# Altium schematic sheet RPI-TPS-GK - USB.SchDoc
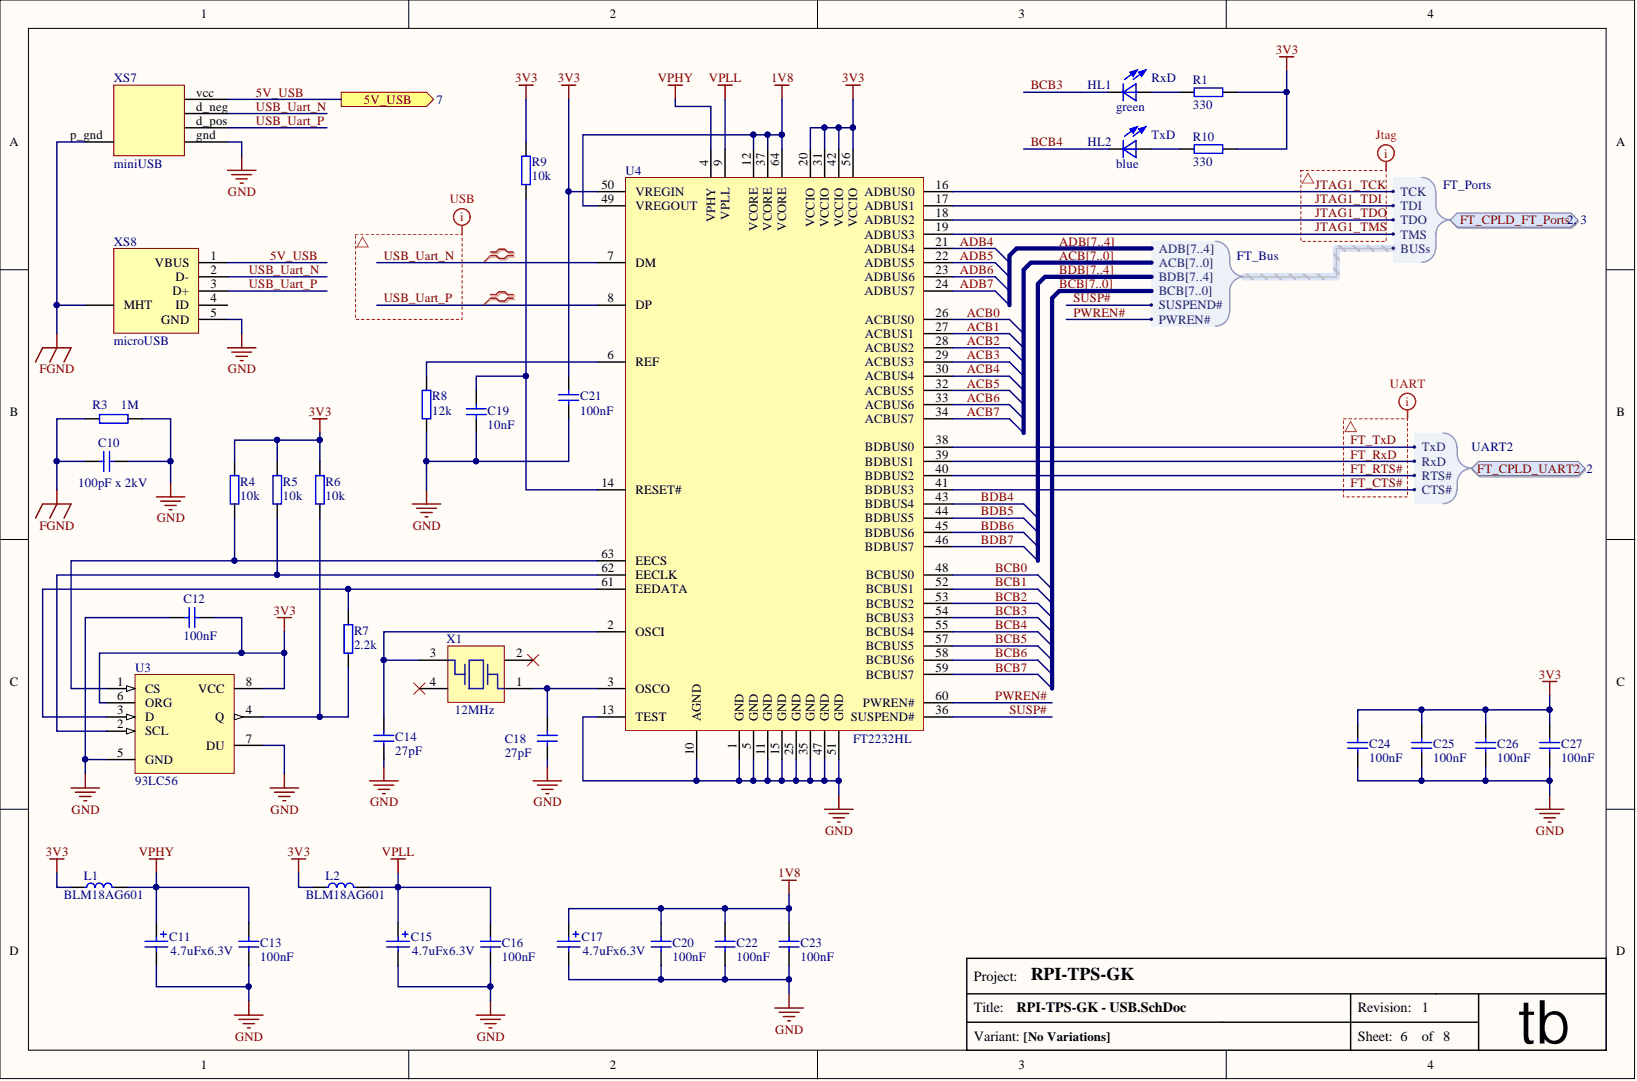
[diagram p0: RPI-TPS-GK - USB.SchDoc]

## Parts
C10  value 100pF x 2kV  [C1206]  (hidden comment: CAP)
C11  value 4.7uFx6.3V  [CAP TAN 0805 2012 SIZE R]  (hidden comment: uF)
C12  value 100nF  [C0603]  (hidden comment: CAP)
C13  value 100nF  [C0603]  (hidden comment: CAP)
C14  value 27pF  [C0603]  (hidden comment: CAP)
C15  value 4.7uFx6.3V  [CAP TAN 0805 2012 SIZE R]  (hidden comment: uF)
C16  value 100nF  [C0603]  (hidden comment: CAP)
C17  value 4.7uFx6.3V  [CAP TAN 0805 2012 SIZE R]  (hidden comment: uF)
C18  value 27pF  [C0603]  (hidden comment: CAP)
C19  value 10nF  [C0603]  (hidden comment: CAP)
C20  value 100nF  [C0603]  (hidden comment: CAP)
C21  value 100nF  [C0603]  (hidden comment: CAP)
C22  value 100nF  [C0603]  (hidden comment: CAP)
C23  value 100nF  [C0603]  (hidden comment: CAP)
C24  value 100nF  [C0603]  (hidden comment: CAP)
C25  value 100nF  [C0603]  (hidden comment: CAP)
C26  value 100nF  [C0603]  (hidden comment: CAP)
C27  value 100nF  [C0603]  (hidden comment: CAP)
HL1  RxD  value green  [0805_LED_green]
HL2  TxD  value blue  [0805_LED_blue]
L1  value BLM18AG601  [ferrite_bead_0603]  (hidden comment: BLM18PG221SN1D)
L2  value BLM18AG601  [ferrite_bead_0603]  (hidden comment: BLM18PG221SN1D)
R1  value 330  [0603_RESISTOR]  (hidden comment: Resistor)
R3  value 1M  [0805_resistor]  (hidden comment: Resistor)
R4  value 10k  [0603_RESISTOR]  (hidden comment: Resistor)
R5  value 10k  [0603_RESISTOR]  (hidden comment: Resistor)
R6  value 10k  [0603_RESISTOR]  (hidden comment: Resistor)
R7  value 2.2k  [0603_RESISTOR]  (hidden comment: Resistor)
R8  value 12k  [0603_RESISTOR]  (hidden comment: Resistor)
R9  value 10k  [0603_RESISTOR]  (hidden comment: Resistor)
R10  value 330  [0603_RESISTOR]  (hidden comment: Resistor)
U3  value 93LC56  [SOIC-8 150 Mil]  (hidden comment: 93xCxx)
U4  FT2232HL  [LQFP64]
X1  value 12MHz  [OSC_CX3225GB]  (hidden comment: OSC_CX3225GB)
XS7  value miniUSB  [MiniUSB_Conn_2types]  (hidden comment: miniUSB_conn)
XS8  microUSB  [MicroUSB47642-0001]

## Ports
5V_USB, FT_CPLD_FT_Ports, FT_CPLD_FT_PORTS.BUSS.ACB0, FT_CPLD_FT_PORTS.BUSS.ACB1, FT_CPLD_FT_PORTS.BUSS.ACB2, FT_CPLD_FT_PORTS.BUSS.ACB3, FT_CPLD_FT_PORTS.BUSS.ACB4, FT_CPLD_FT_PORTS.BUSS.ACB5, FT_CPLD_FT_PORTS.BUSS.ACB6, FT_CPLD_FT_PORTS.BUSS.ACB7, FT_CPLD_FT_Ports.BUSs.ACB[7..0], FT_CPLD_FT_PORTS.BUSS.ADB4, FT_CPLD_FT_PORTS.BUSS.ADB5, FT_CPLD_FT_PORTS.BUSS.ADB6, FT_CPLD_FT_PORTS.BUSS.ADB7, FT_CPLD_FT_Ports.BUSs.ADB[7..4], FT_CPLD_FT_PORTS.BUSS.BCB0, FT_CPLD_FT_PORTS.BUSS.BCB1, FT_CPLD_FT_PORTS.BUSS.BCB2, FT_CPLD_FT_PORTS.BUSS.BCB3, FT_CPLD_FT_PORTS.BUSS.BCB4, FT_CPLD_FT_PORTS.BUSS.BCB5, FT_CPLD_FT_PORTS.BUSS.BCB6, FT_CPLD_FT_PORTS.BUSS.BCB7, FT_CPLD_FT_Ports.BUSs.BCB[7..0], FT_CPLD_FT_PORTS.BUSS.BDB4, FT_CPLD_FT_PORTS.BUSS.BDB5, FT_CPLD_FT_PORTS.BUSS.BDB6, FT_CPLD_FT_PORTS.BUSS.BDB7, FT_CPLD_FT_Ports.BUSs.BDB[7..4], FT_CPLD_FT_Ports.BUSs.PWREN#, FT_CPLD_FT_Ports.BUSs.SUSPEND#, FT_CPLD_FT_Ports.TCK, FT_CPLD_FT_Ports.TDI, FT_CPLD_FT_Ports.TDO, FT_CPLD_FT_Ports.TMS, FT_CPLD_UART2, FT_CPLD_UART2.CTS#, FT_CPLD_UART2.RTS#, FT_CPLD_UART2.RxD, FT_CPLD_UART2.TxD

## Nets
1V8: C17.1 C20.2 C22.2 C23.2 U4.12(VCORE) U4.37(VCORE) U4.49(VREGOUT) U4.64(VCORE)
3V3: C12.1 C21.2 C24.2 C25.2 C26.2 C27.2 L1.1 L2.1 R1.1 R4.2 R5.2 R6.2 R9.2 R10.1 U3.6(ORG) U3.8(VCC) U4.20(VCCIO) U4.31(VCCIO) U4.42(VCCIO) U4.50(VREGIN) U4.56(VCCIO)
FGND: C10.1 R3.1 XS7.p_gnd XS8.MHT
GND: C10.2 C11.2 C12.2 C13.1 C14.1 C15.2 C16.1 C17.2 C18.1 C19.1 C20.1 C21.1 C22.1 C23.1 C24.1 C25.1 C26.1 C27.1 R3.2 R8.1 U3.5(GND) U3.7(DU) U4.1(GND) U4.5(GND) U4.10(AGND) U4.11(GND) U4.13(TEST) U4.15(GND) U4.25(GND) U4.35(GND) U4.47(GND) U4.51(GND) XS7.gnd XS8.5(GND)
VPHY: C11.1 C13.2 L1.2 U4.4(VPHY)
VPLL: C15.1 C16.2 L2.2 U4.9(VPLL)
5V_USB: XS7.vcc XS8.1(VBUS) ; port 5V_USB
ACB0: U4.26(ACBUS0) ; port FT_CPLD_FT_Ports  [also labelled ACB]
ACB1: U4.27(ACBUS1) ; port FT_CPLD_FT_Ports  [also labelled ACB]
ACB2: U4.28(ACBUS2) ; port FT_CPLD_FT_Ports  [also labelled ACB]
ACB3: U4.29(ACBUS3) ; port FT_CPLD_FT_Ports  [also labelled ACB]
ACB4: U4.30(ACBUS4) ; port FT_CPLD_FT_Ports  [also labelled ACB]
ACB5: U4.32(ACBUS5) ; port FT_CPLD_FT_Ports  [also labelled ACB]
ACB6: U4.33(ACBUS6) ; port FT_CPLD_FT_Ports  [also labelled ACB]
ACB7: U4.34(ACBUS7) ; port FT_CPLD_FT_Ports  [also labelled ACB]
ADB4: U4.21(ADBUS4) ; port FT_CPLD_FT_Ports  [also labelled ADB]
ADB5: U4.22(ADBUS5) ; port FT_CPLD_FT_Ports  [also labelled ADB]
ADB6: U4.23(ADBUS6) ; port FT_CPLD_FT_Ports  [also labelled ADB]
ADB7: U4.24(ADBUS7) ; port FT_CPLD_FT_Ports  [also labelled ADB]
BCB0: U4.48(BCBUS0) ; port FT_CPLD_FT_Ports  [also labelled BCB]
BCB1: U4.52(BCBUS1) ; port FT_CPLD_FT_Ports  [also labelled BCB]
BCB2: U4.53(BCBUS2) ; port FT_CPLD_FT_Ports  [also labelled BCB]
BCB3: HL1.2 U4.54(BCBUS3) ; port FT_CPLD_FT_Ports  [also labelled BCB]
BCB4: HL2.2 U4.55(BCBUS4) ; port FT_CPLD_FT_Ports  [also labelled BCB]
BCB5: U4.57(BCBUS5) ; port FT_CPLD_FT_Ports  [also labelled BCB]
BCB6: U4.58(BCBUS6) ; port FT_CPLD_FT_Ports  [also labelled BCB]
BCB7: U4.59(BCBUS7) ; port FT_CPLD_FT_Ports  [also labelled BCB]
BDB4: U4.43(BDBUS4) ; port FT_CPLD_FT_Ports  [also labelled BDB]
BDB5: U4.44(BDBUS5) ; port FT_CPLD_FT_Ports  [also labelled BDB]
BDB6: U4.45(BDBUS6) ; port FT_CPLD_FT_Ports  [also labelled BDB]
BDB7: U4.46(BDBUS7) ; port FT_CPLD_FT_Ports  [also labelled BDB]
FT_CTS#: U4.41(BDBUS3) ; port FT_CPLD_UART2
FT_RTS#: U4.40(BDBUS2) ; port FT_CPLD_UART2
FT_RxD: U4.39(BDBUS1) ; port FT_CPLD_UART2
FT_TxD: U4.38(BDBUS0) ; port FT_CPLD_UART2
JTAG1_TCK: U4.16(ADBUS0) ; port FT_CPLD_FT_Ports
JTAG1_TDI: U4.17(ADBUS1) ; port FT_CPLD_FT_Ports
JTAG1_TDO: U4.18(ADBUS2) ; port FT_CPLD_FT_Ports
JTAG1_TMS: U4.19(ADBUS3) ; port FT_CPLD_FT_Ports
PWREN#: U4.60(PWREN#) ; port FT_CPLD_FT_Ports
SUSP#: U4.36(SUSPEND#) ; port FT_CPLD_FT_Ports
USB_Uart_N: U4.7(DM) XS7.d_neg XS8.2(D-)
USB_Uart_P: U4.8(DP) XS7.d_pos XS8.3(D+)
NetC14_2: C14.2 U4.2(OSCI) X1.3
NetC18_2: C18.2 U4.3(OSCO) X1.1
NetC19_2: C19.2 R9.1 U4.14(RESET#)
NetHL1_1: HL1.1 R1.2
NetHL2_1: HL2.1 R10.2
NetR4_1: R4.1 U3.1(CS) U4.63(EECS)
NetR5_1: R5.1 U3.2(SCL) U4.62(EECLK)
NetR6_1: R6.1 R7.1 U3.4(Q)
NetR7_2: R7.2 U3.3(D) U4.61(EEDATA)
NetR8_2: R8.2 U4.6(REF)
N00246:
N00247:
N00248:

Not connected: X1 2 4; XS8 4(ID)

## Library data of the parts
C10 = CAP: Type of Component: Ceramic Capacitor; Component Kind: Standard; Package / Case: 1206; Supplier 1: aliexpress.com; Supplier 1 Link: aliexpress.com/item/1LOT-50PCS-Chip-Capacitors-100pF-101J-2000V-2kV-5-1206-Package1206-3216-Metric/32561851945.html?spm=2114.03010208.3.118.3xNC69&ws_ab_test=searchweb0_0,searchweb201602_1_10065_10068_10501_10503_10000032_119_10000025_10000029_430_10000028_10060_10062_10056_10055_10000062_10054_10059_10099_10000022_10000012_10103_10000015_10102_10096_10000018_10000019_10000056_10000059_10052_10053_10107_10050_10106_10051_10000053_10000007_10000050_10117_10084_10083_10000047_10080_10082_10081_10110_10111_10112_10113_10114_10115_10000041_10000044_10078_10079_10000038_429_10073_10000035_10121-10503_10501,searchweb201603_1,afswitch_1,single_sort_1_default&btsid=08511054-7293-4023-a14a-e6e313e7b3b7; Supplier 1 Part: 1206 100pF 2kV; Supplier 2: http://imrad.com.ua/; Supplier 2 Link: http://imrad.com.ua/ru/cc1206jknpodbn101; Supplier 2 Part: 198353; Supplier 3: http://voron.ua/; Supplier 3 Link: http://voron.ua/catalog/019223; Supplier 3 Part: 019223; Library Name: RPI-VITA.IntLib
C11 C15 C17 = Cap_pol_tant: Manufacturer: NEC; Manufacturer Part: TEESVP1A475M8R; Type of Component: Tantalum Capacitor; Component Kind: Standard; Package / Case: 0805; Supplier 1: aliexpress.com; Supplier 1 Link: aliexpress.com/item/4-7UF-10V-P-type-0805-2012-SMD-Tantalum-Capacitor-TEESVP1A475M8R-x100PCS-FREE-SHIPPING/2021782850.html?spm=2114.01010208.3.2.okythJ&ws_ab_test=searchweb0_0,searchweb201602_1_10065_10068_10501_10503_10000032_119_10000025_10000029_430_10000028_10060_10062_10056_10055_10000062_10054_10059_10099_10000022_10000012_10103_10000015_10102_10096_10000018_10000019_10000056_10000059_10052_10053_10107_10050_10106_10051_10000053_10000007_10000050_10084_10118_10083_10000047_10080_10082_10081_10110_10111_10112_10113_10114_10115_10000041_10000044_10078_10079_10000038_429_10073_10000035_10121-119_10503_10501,searchweb201603_1,afswitch_1,single_sort_1_default&btsid=1ad9c6e0-95d3-4588-aff6-9bdc2e468ca5; Supplier 1 Part: 0805 4.7uF 6.3V; Library Name: RPI-VITA.IntLib
C12 C13 C16 C20-C27 = CAP: Manufacturer: Vishay / Vitramon; Manufacturer Part: VJ0603Y104JXJPW1BC; Type of Component: Ceramic Capacitor; Component Kind: Standard; Manufacturer Link: http://www.vishay.com/product?docid=28548; Package / Case: 0603; Supplier 1: aliexpress.com; Supplier 1 Link: aliexpress.com/item/100pcs-lot-100nF-X7R-Error-10-50V-0603-smd-capacitor/32318089455.html?spm=2114.03010208.3.2.PDNk2A&ws_ab_test=searchweb201556_8,searchweb201602_1_10065_10068_112_10069_110_111_418_10017_109_108_10060_10061_10062_10057_10039_10056_10055_10054_10059_10058_10073_10070_10052_10053_10050_10051,searchweb201603_1&btsid=c39062ca-3db8-4562-8db0-c782461646c7; Supplier 2: http://imrad.com.ua/; Supplier 2 Link: http://imrad.com.ua/ru/cc0603krx7r8bb104; Supplier 2 Part: 182925; Supplier 3: http://voron.ua; Supplier 3 Link: http://voron.ua/catalog/001832; Supplier 3 Part: 001832; Library Name: RPI-VITA.IntLib
C14 C18 = CAP: Manufacturer: Vishay / Vitramon; Manufacturer Part: VJ0603A270GXAPW1BC; Type of Component: Ceramic Capacitor; Component Kind: Standard; Manufacturer Link: http://www.vishay.com/product?docid=28548; Package / Case: 0603; Supplier 1: aliexpress.com; Supplier 1 Link: aliexpress.com/item/1LOT-100PCS-Chip-Capacitors-27pF-270J-50V-5-0603-Package0603-1608-Metric/32561911564.html?spm=2114.01010208.3.12.XP8dkk&ws_ab_test=searchweb0_0,searchweb201602_1_10065_10068_10501_10503_10000032_119_10000025_10000029_430_10000028_10060_10062_10056_10055_10000062_10054_10059_10099_10000022_10000012_10103_10000015_10102_10096_10000018_10000019_10000056_10000059_10052_10053_10107_10050_10106_10051_10000053_10000007_10000050_10084_10118_10083_10000047_10080_10082_10081_10110_10111_10112_10113_10114_10115_10000041_10000044_10078_10079_10000038_429_10073_10000035_10121-10503_10501,searchweb201603_1,afswitch_1,single_sort_1_default&btsid=7449e9ee-25f8-407e-b73f-e18288cc212b; Supplier 1 Part: 0603 27pF; Supplier 2: http://imrad.com.ua/; Supplier 2 Link: http://imrad.com.ua/ru/cc0603jrnpo9bn270; Supplier 2 Part: 183055; Library Name: RPI-VITA.IntLib
C19 = CAP: Manufacturer: Vishay / Vitramon; Manufacturer Part: VJ0603Y103KXACW1BC; Type of Component: Ceramic Capacitor; Component Kind: Standard; Manufacturer Link: http://www.vishay.com/product?docid=28548; Package / Case: 0603; Supplier 1: aliexpress.com; Supplier 1 Link: aliexpress.com/item/Free-Shopping-100PCS-0603-10NF-103-0-01uF-50V-10-50v-X7R-Ceramic-capacitors-Good-Quality/1090724777.html?spm=2114.01010208.3.22.dy0dqC&ws_ab_test=searchweb0_0,searchweb201602_1_10065_10068_10501_10503_10000032_119_10000025_10000029_430_10000028_10060_10062_10056_10055_10000062_10054_10059_10099_10000022_10000012_10103_10000015_10102_10096_10000018_10000019_10000056_10000059_10052_10053_10107_10050_10106_10051_10000053_10000007_10000050_10084_10118_10083_10000047_10080_10082_10081_10110_10111_10112_10113_10114_10115_10000041_10000044_10078_10079_10000038_429_10073_10000035_10121-10503_10501,searchweb201603_1,afswitch_1,single_sort_1_default&btsid=8193d816-c2c6-46a7-a3e7-3206b4f88985; Supplier 1 Part: 0603 10nF; Supplier 2: http://imrad.com.ua/; Supplier 2 Link: http://imrad.com.ua/ru/cercap-0-01-50v-0603-kx7r-product-99103; Supplier 2 Part: 176847; Supplier 3: http://voron.ua/; Supplier 3 Link: http://voron.ua/catalog/001833; Supplier 3 Part: 001833; Library Name: RPI-VITA.IntLib
HL1 = LED: Manufacturer Part: 0805 Led Green; Component Kind: Standard; Package / Case: 0805; Supplier 1: aliexpress.com; Supplier 1 Link: aliexpress.com/store/product/FREE-SHIPPING-1000PCS-0805-2012-Green-Clear-Ultra-Bright-SMD-LED-Indication/102034_32595423201.html?storeId=102034&spm=2114.12010208.100007.36.H0BBkE; Supplier 1 Part: 0805 Led Green; Supplier 2: http://imrad.com.ua/; Supplier 2 Link: http://imrad.com.ua/ru/fyls-0805gc; Supplier 2 Part: 166642; Supplier 3: http://voron.ua/; Supplier 3 Link: http://voron.ua/catalog/019519; Supplier 3 Part: 019519; Type of Component: Led; Library Name: RPI-VITA.IntLib
HL2 = LED: Manufacturer Part: 0805 Led Blue; Component Kind: Standard; Package / Case: 0805; Supplier 1: aliexpress.com; Supplier 1 Link: aliexpress.com/store/product/FREE-SHIPPING-1000PCS-0805-2012-Blue-Clear-Ultra-Bright-SMD-LED-Indication/102034_32593006615.html?storeId=102034&spm=2114.12010208.100007.33.hkzVBq; Supplier 1 Part: 0805 Led Blue; Supplier 2: http://imrad.com.ua/; Supplier 2 Link: http://imrad.com.ua/ru/fyls-0805ubc-pbf; Supplier 2 Part: 100043; Supplier 3: http://voron.ua/; Supplier 3 Link: http://voron.ua/catalog/016316; Supplier 3 Part: 016316; Type of Component: Led; Library Name: RPI-VITA.IntLib
L1 L2 = BLM18PG221SN1D: ferrite bead 0603; Manufacturer: Murata; Manufacturer Part: BLM18AG601SN1D; Type of Component: EMI Filter Beads; Component Kind: Standard; Manufacturer Link: http://search.murata.co.jp/Ceramy/CatalogAction.do?sHinnm=BLM11A601S&sNHinnm=BLM18AG601SN1&sNhin_key=BLM18AG601SN1D&sLang=en&sParam=BLM18AG601SN1D; Package / Case: 0603; Supplier 2: http://imrad.com.ua/; Supplier 2 Link: http://imrad.com.ua/ru/blm18ag601sn1d; Supplier 2 Part: 155530; Library Name: RPI-VITA.IntLib
R1 R10 = Resistor: Manufacturer: UniOhm; Manufacturer Part: 0603 330; Component Kind: Standard; Package / Case: 0603; Supplier 1: aliexpress.com; Supplier 1 Link: aliexpress.com/store/product/0603-SMD-Resistor-1-330-ohm-5000pcs-lot/506373_32307375865.html?detailNewVersion=&categoryId=400503&spm=2114.12010208.1000023.227.iOPgvR; Supplier 1 Part: 0603 330; Supplier 2: http://imrad.com.ua/; Supplier 2 Link: http://imrad.com.ua/ru/res-0603-330r-1; Supplier 2 Part: 181872; Type of Component: Resistor; Library Name: RPI-VITA.IntLib
R3 = Resistor: Manufacturer: Vishay / Dale; Manufacturer Part: CRCW08051M00FKEA; Component Kind: Standard; Manufacturer Link: http://www.vishay.com/product?docid=20035; Package / Case: 0805; Supplier 1: aliexpress.com; Supplier 1 Link: aliexpress.com/item/SMD-Resistance-0805-1M-1-1-8W-Chip-Resistors-100PCS-LOT/32522127065.html?spm=2114.03010208.3.41.Wyav5y&ws_ab_test=searchweb0_0,searchweb201602_1_10065_10068_10501_10503_10000032_119_10000025_10000029_430_10000028_10060_10062_10056_10055_10000062_10054_10059_10099_10000022_10000012_10103_10000015_10102_10096_10000018_10000019_10000056_10000059_10052_10053_10107_10050_10106_10051_10000053_10000007_10000050_10117_10084_10083_10000047_10080_10082_10081_10110_10111_10112_10113_10114_10115_10000041_10000044_10078_10079_10000038_429_10073_10000035_10121-10503_10501,searchweb201603_1,afswitch_1,single_sort_1_default&btsid=f6a42976-96cd-4274-b20d-158de608f2dc; Supplier 1 Part: 0805 1M; Supplier 2: http://imrad.com.ua/; Supplier 2 Link: http://imrad.com.ua/ru/rc0805fr-071ml; Supplier 2 Part: 182706; Supplier 3: http://voron.ua/; Supplier 3 Link: http://voron.ua/catalog/002143; Supplier 3 Part: 002143; Type of Component: Resistor; Library Name: RPI-VITA.IntLib
R4-R6 R9 = Resistor: Manufacturer: Vishay / Dale; Manufacturer Part: CRCW060310K0FKEA; Component Kind: Standard; Manufacturer Link: http://www.vishay.com/product?docid=20035; Package / Case: 0603; Supplier 1: aliexpress.com; Supplier 1 Link: aliexpress.com/store/product/0603-SMD-Resistor-5-10K-ohm-5000pcs-lot/506373_32307351301.html?storeId=506373&spm=2114.12010208.100007.103.XAQnpm; Supplier 1 Part: 0603 10k; Supplier 2: http://imrad.com.ua/; Supplier 2 Link: http://imrad.com.ua/ru/rc0603jr-0710kl; Supplier 2 Part: 183440; Supplier 3: http://www.kosmodrom.com.ua; Supplier 3 Link: http://www.kosmodrom.com.ua/prodlist.php?page=0&name=%280603%29+10+kom&okbutton=%CF%EE%E8%F1%EA+%EF%EE+%F1%EA%EB%E0%E4%F3; Supplier 3 Part: (0603) 10 kom; Type of Component: Resistor; Library Name: RPI-VITA.IntLib
R7 = Resistor: Manufacturer: Vishay / Dale; Manufacturer Part: CRCW06032K20JNEA; Component Kind: Standard; Manufacturer Link: http://www.vishay.com/product?docid=20035; Package / Case: 0603; Supplier 1: aliexpress.com; Supplier 1 Link: aliexpress.com/store/product/0603-SMD-Resistor-5-2-2K-ohm-5000pcs-lot/506373_32308080995.html?spm=2114.12010108.1000023.109.DtVsO1; Supplier 1 Part: 0603 2.2k; Supplier 2: http://imrad.com.ua/; Supplier 2 Link: http://imrad.com.ua/ru/res-0603-2k2-5-product-70186; Supplier 2 Part: 147328; Supplier 3: http://voron.ua/; Supplier 3 Link: http://voron.ua/catalog/023266; Supplier 3 Part: 023266; Type of Component: Resistor; Library Name: RPI-VITA.IntLib
R8 = Resistor: Manufacturer: Vishay / Dale; Manufacturer Part: CRCW060312K0FKEA; Component Kind: Standard; Manufacturer Link: http://www.vishay.com/product?docid=20035; Package / Case: 0603; Supplier 1: aliexpress.com; Supplier 1 Link: aliexpress.com/item/Free-Shipping-100PCS-0603-12K-12K-OHM-5-smd-resistor/1871918358.html?spm=2114.01010208.3.43.AuqUxr&ws_ab_test=searchweb0_0,searchweb201602_1_10065_10068_10501_10503_10000032_119_10000025_10000029_430_10000028_10060_10062_10056_10055_10000062_10054_10059_10099_10000022_10000012_10103_10000015_10102_10096_10000018_10000019_10000056_10000059_10052_10053_10107_10050_10106_10051_10000053_10000007_10000050_10084_10118_10083_10000047_10080_10082_10081_10110_10111_10112_10113_10114_10115_10000041_10000044_10078_10079_10000038_429_10073_10000035_10121-10503_10501,searchweb201603_1,afswitch_1,single_sort_1_default&btsid=5caa854b-9869-4055-9d65-88f7445dd534; Supplier 1 Part: 0603 12k; Supplier 2: http://imrad.com.ua/; Supplier 2 Link: http://imrad.com.ua/ru/rc0603jr-0712kl; Supplier 2 Part: 194449; Supplier 3: http://voron.ua/; Supplier 3 Link: http://voron.ua/catalog/023603; Supplier 3 Part: 023603; Type of Component: Resistor; Library Name: RPI-VITA.IntLib
U3 = 93xCxx: eeprom; Manufacturer: Microchip Technology; Manufacturer Part: 93LC56B-I/SN; Component Kind: Standard; Manufacturer Link: http://www.microchip.com/wwwproducts/Devices.aspx?product=93LC56C; Package / Case: SOIC-8; Supplier 1: aliexpress.com; Supplier 1 Link: aliexpress.com/item/93LC56B-I-SN-93LC56BT-I-SN-93LC56BI-93LC56B-SOP8/32775841396.html?spm=2114.01010208.3.2.d8tY6w&ws_ab_test=searchweb0_0,searchweb201602_1_10065_10068_10501_10503_10000032_119_10000025_10000029_430_10000028_10060_10062_10056_10055_10000062_10054_10059_10099_10000022_10000012_10103_10000015_10102_10096_10000018_10000019_10000056_10000059_10052_10053_10107_10050_10106_10051_10000053_10000007_10000050_10084_10118_10083_10000047_10080_10082_10081_10110_10111_10112_10113_10114_10115_10000041_10000044_10078_10079_10000038_429_10073_10000035_10121-10503_10501,searchweb201603_1,afswitch_1,single_sort_1_default&btsid=ce167b10-cf56-4f40-b041-319f5144c1ab; Supplier 1 Part: 93LC56B-I/SN; Supplier 2: http://imrad.com.ua/; Supplier 2 Link: http://imrad.com.ua/ru/93lc56b-i-sn; Supplier 2 Part: 145686; Type of Component: EEPROM; Library Name: RPI-VITA.IntLib
U4 = FT2232HL: Manufacturer: FTDI; Type of Component: USB Interface IC; Component Kind: Standard; Manufacturer Link: http://www.ftdichip.com/Products/ICs/FT2232H.htm; Manufacturer Part: FT2232HL; Package / Case: LQFP-64; Supplier 1: aliexpress.com; Supplier 1 Link: aliexpress.com/item/FT2232HL-FT2232-FTDI-LQFP-64-IC-10pcs-lot-Free-shipping/32371977037.html?spm=2114.03010208.3.20.fTeT2B&ws_ab_test=searchweb0_0,searchweb201602_1_10065_10068_10501_10503_10000032_119_10000025_10000029_430_10000028_10060_10062_10056_10055_10000062_10054_10059_10099_10000022_10000012_10103_10000015_10102_10096_10000018_10000019_10000056_10000059_10052_10053_10107_10050_10106_10051_10000053_10000007_10000050_10117_10084_10083_10000047_10080_10082_10081_10110_10111_10112_10113_10114_10115_10000041_10000044_10078_10079_10000038_429_10073_10000035_10121-10503_10501,searchweb201603_1,afswitch_1,single_sort_1_default&btsid=652c4dd5-d57b-4a04-907e-91a25d69b377; Supplier 1 Part: FT2232HL; Supplier 2: http://imrad.com.ua/; Supplier 2 Link: http://imrad.com.ua/ru/ft2232hl-r; Supplier 2 Part: 155919; Value: FT2232HL; Library Name: RPI-VITA.IntLib
X1 = OSC_CX3225GB: Manufacturer: Kyocera; Manufacturer Part: CX3225GB12000P0HPQCC; Type of Component: Crystal Quartz; Component Kind: Standard; Manufacturer Link: http://www.kyocera-crystal.jp/eng/prdct/list/xtal/; Package / Case: 3.2 mm x 2.5 mm; Supplier 1: aliexpress.com; Supplier 1 Link: aliexpress.com/item/Free-shiiping-10pcs-12MHz-20ppm-20pF-3225-smd-quartz-resonator-Crystal-oscillator/32326262359.html?spm=2114.01010208.3.27.qvYCKN&ws_ab_test=searchweb0_0,searchweb201602_1_10065_10068_10501_10503_10000032_119_10000025_10000029_430_10000028_10060_10062_10056_10055_10000062_10054_10059_10099_10000022_10000012_10103_10000015_10102_10096_10000018_10000019_10000056_10000059_10052_10053_10107_10050_10106_10051_10000053_10000007_10000050_10084_10118_10083_10000047_10080_10082_10081_10110_10111_10112_10113_10114_10115_10000041_10000044_10078_10079_10000038_429_10073_10000035_10121-10503_10501,searchweb201603_1,afswitch_1,single_sort_1_default&btsid=59fb8a07-753f-4ab6-a536-288ecc6c15ce; Supplier 2: http://imrad.com.ua/; Supplier 2 Link: http://imrad.com.ua/ru/kx-7t-12-0-mhz; Supplier 2 Part: 174081; Library Name: RPI-VITA.IntLib
XS7 = miniUSB_conn: mini USB Connector; Type of Component: Connector miniUSB; Component Kind: Standard; Package / Case: miniUSB 5 pin; Supplier 1: aliexpress.com; Supplier 1 Link: aliexpress.com/item/Free-shipping-200pcs-5pin-SMD-miniUSB-socket-female-SMD-mini-USB-plug-seat-connector-good-quality/731258060.html?spm=2114.01010208.3.1.V77KhM&ws_ab_test=searchweb201556_8%2Csearchweb201602_2_10017_10021_507_10022_10020_10009_9911_10008_10018_10019_101%2Csearchweb201603_1&btsid=9d837896-e768-4de2-adfc-8f6e52cb39fb; Supplier 1 Part: miniUSB 5 pin; Supplier 2: http://imrad.com.ua/; Supplier 2 Link: http://imrad.com.ua/ru/razem-usb-mini-05-bf-smd; Supplier 2 Part: 214205; Supplier 3: http://voron.ua/; Supplier 3 Link: http://voron.ua/catalog/015184; Supplier 3 Part: 015184; Library Name: RPI-VITA.IntLib
XS8 = microUSB_conn: mini USB Connector; Type of Component: Connector microUSB; Value: microUSB 5p; Component Kind: Standard; Package / Case: 5 pin; Supplier 1: aliexpress.com; Supplier 1 Link: aliexpress.com/item/50PCS-10-PCS-LOT-free-shippingAll-copper-MicroUSB-socket-MK5P-Mike-5P-MINIUSB-Micro-USB-female/32310556625.html?spm=2114.03010208.3.185.9X3VVt&ws_ab_test=searchweb201556_1,searchweb201644_1_505_506_503_504_502_10001_10002_10017_10010_10005_10011_10006_10003_10004_10009_10007_10008,searchweb201560_5,searchweb1451318400_-1,searchweb1451318411_6452&btsid=8bbba286-9188-46c9-84b7-d50fd2d22579; Library Name: RPI-VITA.IntLib
